# Altium schematic sheet overview.SchDoc
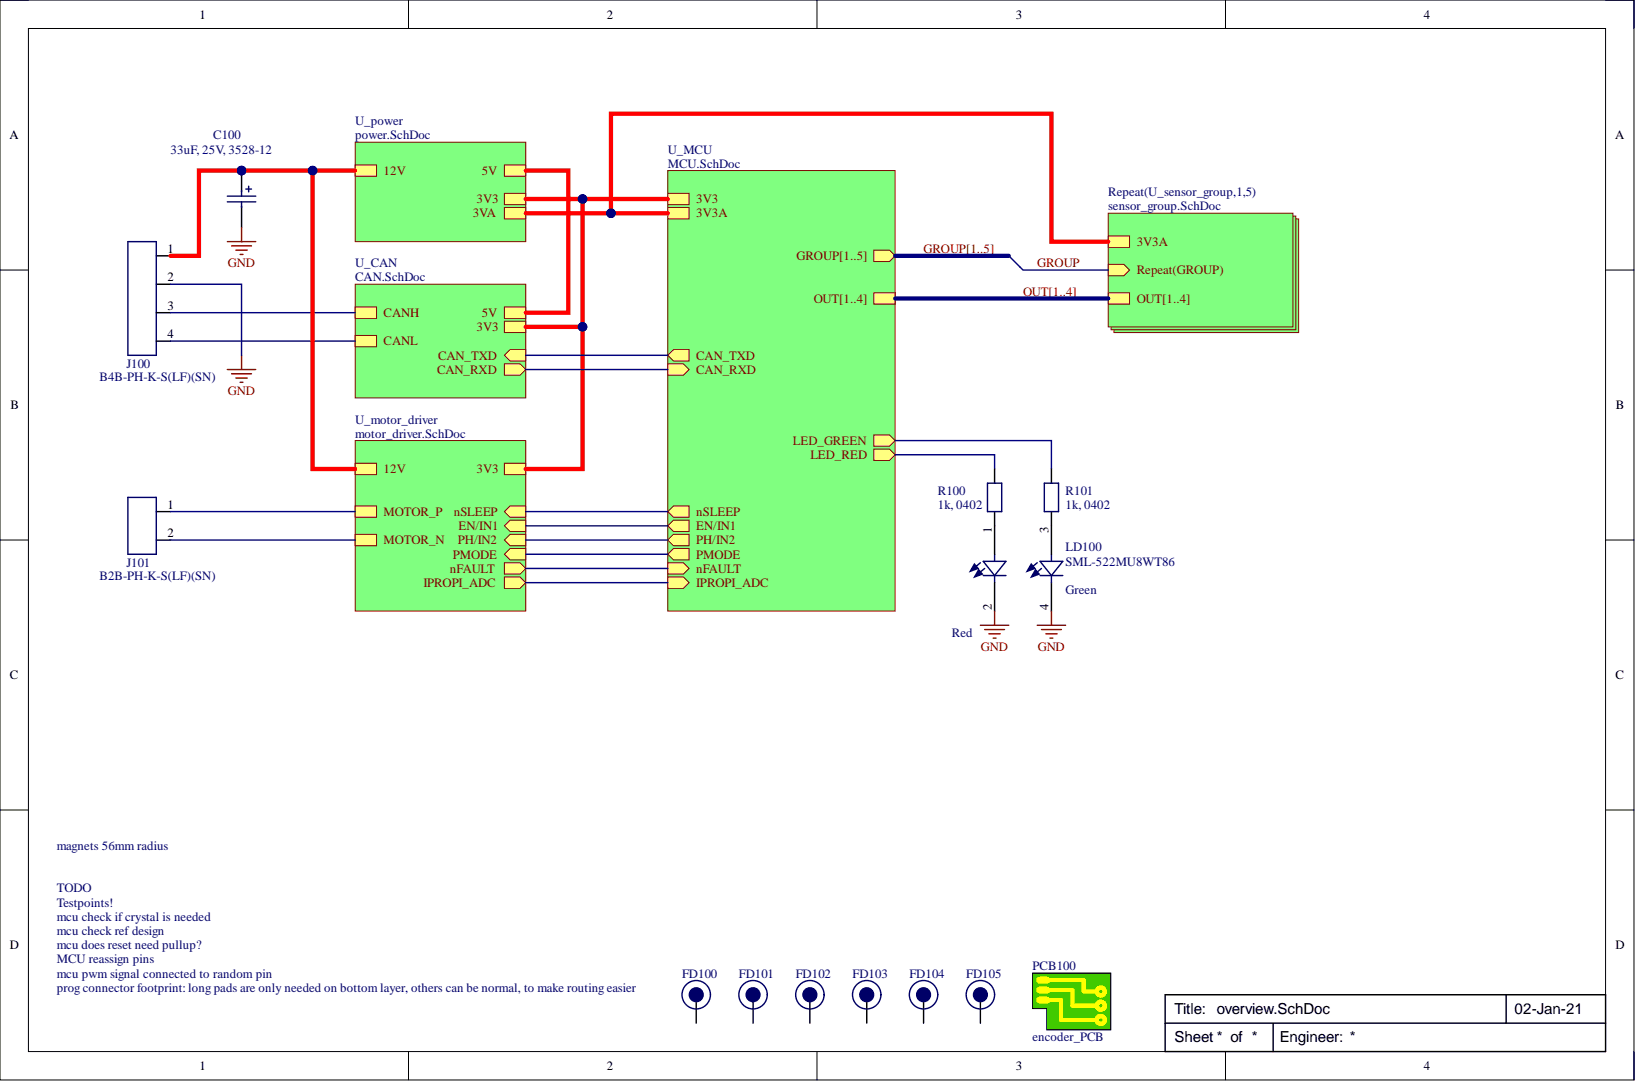
[diagram p0: overview.SchDoc]

## Parts
C100  33uF, 25V, 3528-12  [CAPMP3528X12N]
FD100  1mm  [Fiducial 1x2mm]  (value not drawn)
FD101  1mm  [Fiducial 1x2mm]  (value not drawn)
FD102  1mm  [Fiducial 1x2mm]  (value not drawn)
FD103  1mm  [Fiducial 1x2mm]  (value not drawn)
FD104  1mm  [Fiducial 1x2mm]  (value not drawn)
FD105  1mm  [Fiducial 1x2mm]  (value not drawn)
J100  B4B-PH-K-S(LF)(SN)  [B4B-PH-KL]
J101  B2B-PH-K-S(LF)(SN)  [B2B-PH-KL]
LD100  SML-522MU8WT86  [SML52]
PCB100  encoder_PCB  (mechanical)
R100  1k, 0402  [1005 [0402] RES]
R101  1k, 0402  [1005 [0402] RES]

## Nets
GND: C100.2 J100.2 LD100.2 LD100.4
NetC100_1: C100.1 J100.1
NetFD100_1: FD100.1
NetFD101_1: FD101.1
NetFD102_1: FD102.1
NetFD103_1: FD103.1
NetFD104_1: FD104.1
NetFD105_1: FD105.1
NetJ100_3: J100.3
NetJ100_4: J100.4
NetJ101_1: J101.1
NetJ101_2: J101.2
NetLD100_1: LD100.1 R100.2
NetLD100_3: LD100.3 R101.2
NetR100_1: R100.1
NetR101_1: R101.1
3V3:
3V3A:
5V:
CAN_RXD:
CAN_TXD:
EN/IN1:
GROUP1:  [also labelled GROUP]
GROUP2:  [also labelled GROUP]
GROUP3:  [also labelled GROUP]
GROUP4:  [also labelled GROUP]
GROUP5:  [also labelled GROUP]
IPROPI_ADC:
nFAULT:
nSLEEP:
OUT1:  [also labelled OUT]
OUT2:  [also labelled OUT]
OUT3:  [also labelled OUT]
OUT4:  [also labelled OUT]
PH/IN2:
PMODE:

## Library data of the parts
C100 = Capacitor Polarized: Manufacturer: AVX Corporation; Product Name: TCNT336M025R0250; Component Kind: Standard; Datasheet: http://datasheets.avx.com/J-CAP.pdf; Library Name: as_lib.IntLib; Price: 0.99; Supplier: Digi-Key; Supplier Number: 478-12522-1-ND
FD100-FD105 = Fiducial: Component Kind: Standard; Library Name: as_lib.IntLib
J100 = Connector 4-way: Manufacturer: JST; Notes: Mate PHR-4; Component Kind: Standard; Datasheet: http://www.jst-mfg.com/product/pdf/eng/ePH.pdf?5f95917841eb0; Library Name: as_lib.IntLib; Price: 0.2; Product Name: B4B-PH-K-S(LF)(SN); Supplier: Digi-Key; Supplier Number: 455-1706-ND
J101 = Connector 2-way: Manufacturer: JST; Notes: Mate PHR-2; Component Kind: Standard; Datasheet: http://www.jst-mfg.com/product/pdf/eng/ePH.pdf?5f95917841eb0; Library Name: as_lib.IntLib; Price: 0.14; Product Name: B2B-PH-K-S(LF)(SN); Supplier: Digi-Key; Supplier Number: 455-1704-ND
LD100 = SML-522MU8WT86: Manufacturer: Rohm Semiconductor; Component Kind: Standard; Datasheet: https://fscdn.rohm.com/en/products/databook/datasheet/opto/led/chip_multi/sml-52-e.pdf; Library Name: project_components.SchLib; Price: 0.55; Product Name: SML-522MU8WT86; Supplier: Digi-key; Supplier Number: 846-1208-1-ND
PCB100 = PCB: Library Name: as_lib.IntLib
R100 R101 = Resistor: Manufacturer: Yageo; Product Name: RT0402FRE071KL; Component Kind: Standard; Library Name: as_lib.IntLib; Price: 0.09; Supplier: Digi-Key; Supplier Number: YAG1234CT-ND
